# Altium schematic sheet Audio.SchDoc(Audio)
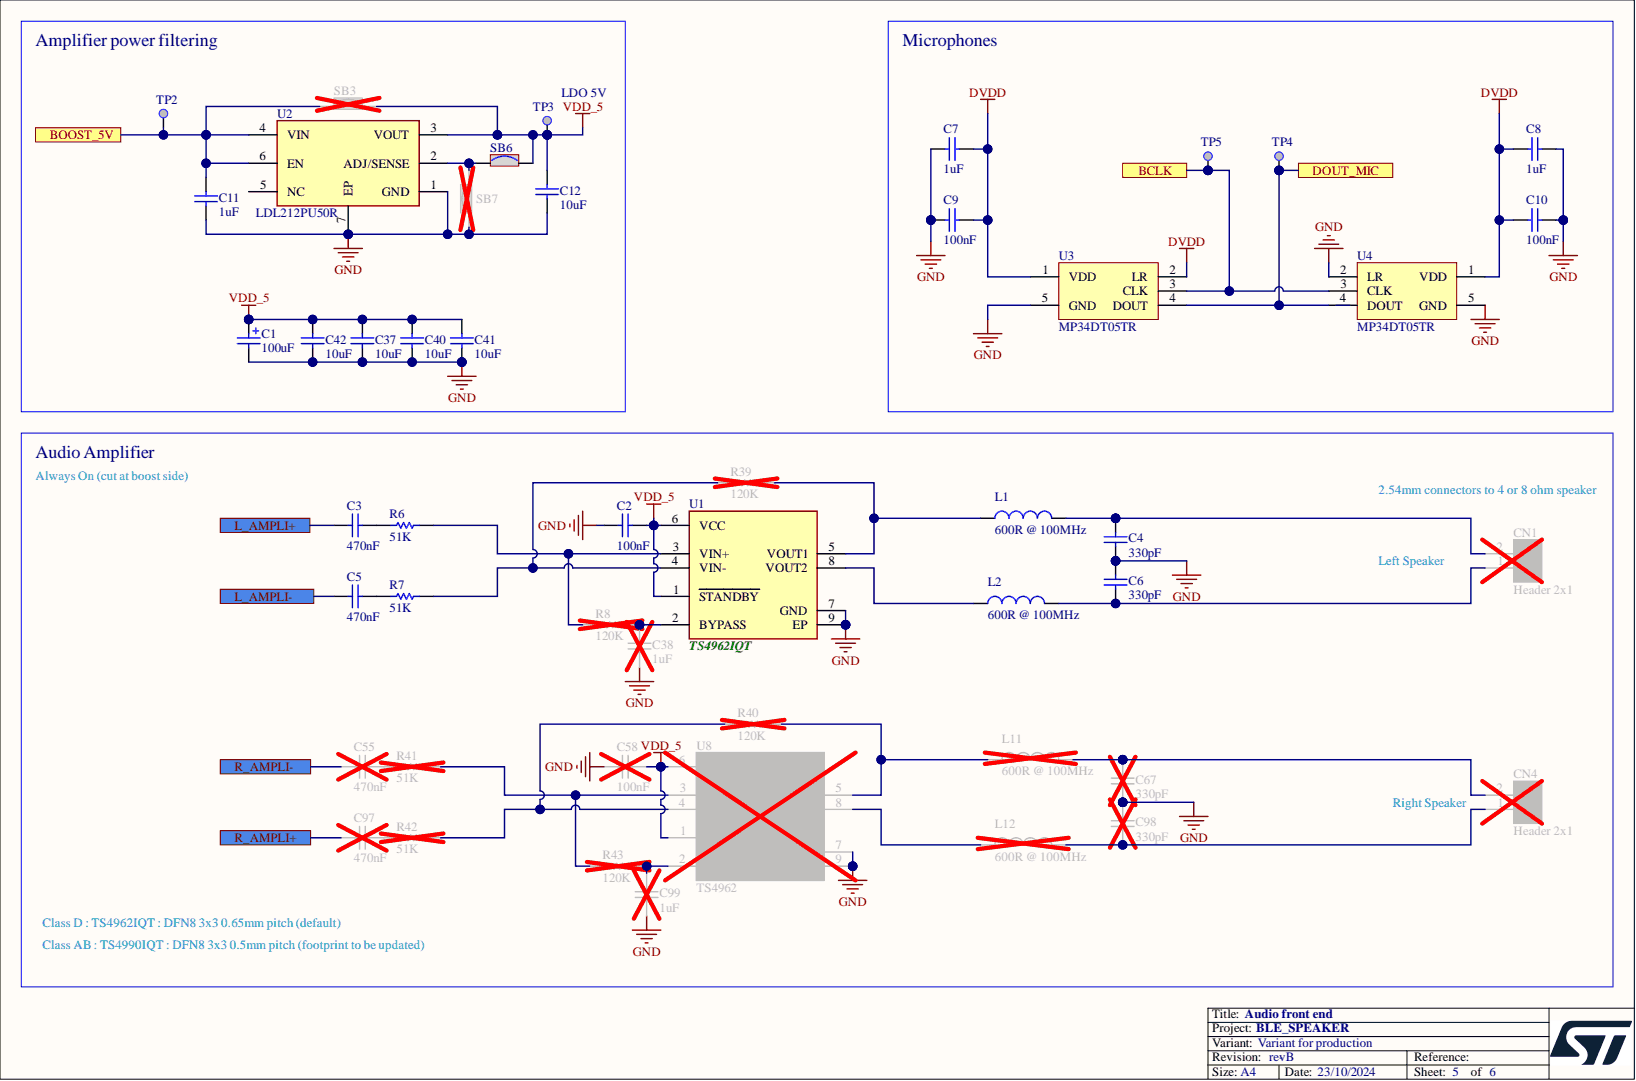
[diagram p0: Audio.SchDoc]

## Parts
C1  value 100uF  [CPOL_TCJ-B_1210_H210]  (hidden comment: CAP_POL)
C2  value 100nF  [C0201_H0P3]  (hidden comment: Capacitor)
C3  value 470nF  [C0402]  (hidden comment: Capacitor)
C4  value 330pF  [C0402]  (hidden comment: Capacitor)
C5  value 470nF  [C0402]  (hidden comment: Capacitor)
C6  value 330pF  [C0402]  (hidden comment: Capacitor)
C7  value 1uF  [C0402]  (hidden comment: Capacitor)
C8  value 1uF  [C0402]  (hidden comment: Capacitor)
C9  value 100nF  [C0201_H0P3]  (hidden comment: Capacitor)
C10  value 100nF  [C0201_H0P3]  (hidden comment: Capacitor)
C11  value 1uF  [C0402]  (hidden comment: Capacitor)
C12  value 10uF  [C0402]  (hidden comment: Capacitor)
C37  value 10uF  [C0402]  (hidden comment: Capacitor)
C38  value 1uF  [C0402]  (hidden comment: Capacitor)
C40  value 10uF  [C0402]  (hidden comment: Capacitor)
C41  value 10uF  [C0402]  (hidden comment: Capacitor)
C42  value 10uF  [C0402]  (hidden comment: Capacitor)
C55  value 470nF  [C0402]  (hidden comment: Capacitor)
C58  value 100nF  [C0201_H0P3]  (hidden comment: Capacitor)
C67  value 330pF  [C0402]  (hidden comment: Capacitor)
C97  value 470nF  [C0402]  (hidden comment: Capacitor)
C98  value 330pF  [C0402]  (hidden comment: Capacitor)
C99  value 1uF  [C0402]  (hidden comment: Capacitor)
CN1  Header 2x1  [CON_SAMTEC_TSW-102-07-G-S]
CN4  Header 2x1  [CON_SAMTEC_TSW-102-07-G-S]
L1  value 600R @ 100MHz  [IND_0603_H0P8]  (hidden comment: Inductor)
L2  value 600R @ 100MHz  [IND_0603_H0P8]  (hidden comment: Inductor)
L11  value 600R @ 100MHz  [IND_0603_H0P8]  (hidden comment: Inductor)
L12  value 600R @ 100MHz  [IND_0603_H0P8]  (hidden comment: Inductor)
R6  value 51K  [R0402]  (hidden comment: Resistor)
R7  value 51K  [R0402]  (hidden comment: Resistor)
R8  value 120K  [R0402]  (hidden comment: Resistor)
R39  value 120K  [R0402]  (hidden comment: Resistor)
R40  value 120K  [R0402]  (hidden comment: Resistor)
R41  value 51K  [R0402]  (hidden comment: Resistor)
R42  value 51K  [R0402]  (hidden comment: Resistor)
R43  value 120K  [R0402]  (hidden comment: Resistor)
SB3  SB_ON  [SOLDER-BRIDGE_R0201_0R]  (value not drawn)
SB6  SB_ON  [SOLDER-BRIDGE_R0201_0R]  (value not drawn)
SB7  SB_ON  [SOLDER-BRIDGE_R0201_0R]  (value not drawn)
TP2  Test Point  [TP_SMD_1MM]  (value not drawn)  (no BOM)
TP3  Test Point  [TP_SMD_1MM]  (value not drawn)  (no BOM)
TP4  Test Point  [TP_SMD_1MM]  (value not drawn)  (no BOM)
TP5  Test Point  [TP_SMD_1MM]  (value not drawn)  (no BOM)
U1  TS4962IQT  [SON50P300X300X100-9N-D]
U2  LDL212PU50R  [SON95P300X300X100-7N-D]
U3  MP34DT05TR  [MIC_HCLGA_3X4X1_4LD]
U4  MP34DT05TR  [MIC_HCLGA_3X4X1_4LD]
U8  TS4962  [SON50P300X300X100-9N-D]

## Ports
BCLK, BOOST_5V, DOUT_MIC, L_AMPLI+, L_AMPLI-, R_AMPLI+, R_AMPLI-

## Nets
DVDD: C7.1 C8.1 C9.2 C10.2 U3.1(VDD) U3.2(LR) U4.1(VDD)
GND: C1.2 C2.1 C4.2 C6.1 C7.2 C8.2 C9.1 C10.1 C11.2 C12.2 C37.2 C38.1 C40.2 C41.2 C42.2 C58.2 C67.2 C98.1 C99.1 SB7.2 U1.7(GND) U1.9(EP) U2.1(GND) U2.7(EP) U3.5(GND) U4.2(LR) U4.5(GND) U8.7(GND) U8.9(EP)
VDD_5: C1.1 C2.2 C12.1 C37.1 C40.1 C41.1 C42.1 C58.1 SB3.2 SB6.1 TP3.1 U1.1(STANDBY) U1.6(VCC) U2.3(VOUT) U8.1(STANDBY) U8.6(VCC)
BCLK: TP5.1 U3.3(CLK) U4.3(CLK) ; port BCLK
BOOST_5V: C11.1 SB3.1 TP2.1 U2.4(VIN) U2.6(EN) ; port BOOST_5V
DOUT_MIC: TP4.1 U3.4(DOUT) U4.4(DOUT) ; port DOUT_MIC
NetC3_1: C3.1 R6.2
NetC3_2: C3.2
NetC4_1: C4.1 CN1.2 L1.2
NetC5_1: C5.1 R7.2
NetC5_2: C5.2
NetC6_2: C6.2 CN1.1 L2.2
NetC38_2: C38.2 R8.1 U1.2(BYPASS)
NetC55_1: C55.1 R41.2
NetC55_2: C55.2
NetC67_1: C67.1 CN4.2 L11.2
NetC97_1: C97.1 R42.2
NetC97_2: C97.2
NetC98_2: C98.2 CN4.1 L12.2
NetC99_2: C99.2 R43.1 U8.2(BYPASS)
NetL1_1: L1.1 R39.1 U1.5(VOUT1)
NetL2_1: L2.1 U1.8(VOUT2)
NetL11_1: L11.1 R40.1 U8.5(VOUT1)
NetL12_1: L12.1 U8.8(VOUT2)
NetR6_1: R6.1 R8.2 U1.3(VIN+)
NetR7_1: R7.1 R39.2 U1.4(VIN-)
NetR40_2: R40.2 R42.1 U8.4(VIN-)
NetR41_1: R41.1 R43.2 U8.3(VIN+)
NetSB6_2: SB6.2 SB7.1 U2.2(ADJ/SENSE)

Not connected: U2 5(NC)

## Library data of the parts
C1 = MCD-01796: Capacitor, Tantalum, 100uF, 6V3, 40mR, +/-20%,  Type_B (3528 metric), T=1.9mm; Manufacturer: AVX; Manufacturer_Part_Number: TCJB107M006R0040; Part_Preference: Recommended; Component_Country_Of_Origin: Czech Republic; Database Table Name: MCD_PARTS$; ID: 3896; ShowFields: YES; Component Kind: Standard; ComponentLink1URL: http://tunmcdsvn.tun.st.com/Component_Documentation_Library/Trunk/Capacitor/AVX_TCJ_Series; Internal_Part_Number: MCD-01796; Library Name: MCD_PARTS_database.DbLib; Order_Code_Digikey: 478-9994-2-ND; Order_Code_Farnell: 2728622; Order_Code_RS: N/A; Package: 3528 (metric); Part_Type: Capacitor; Revision: July-2002: Re-released for DXP Platform.; Status: Released
C2 C9 C10 C58 = MCD-00697: Capacitor, MLCC, 100nF,10V, X5R, +/-10%, 0201, T=0.3mm; Manufacturer: TDK; Manufacturer_Part_Number: C0603X5R1A104K030BC; Part_Preference: Recommended; Component_Country_Of_Origin: Unknown; Database Table Name: MCD_PARTS$; ID: 3046; ShowFields: YES; Component Kind: Standard; ComponentLink1URL: http://tunmcdsvn.tun.st.com/Component_Documentation_Library/Trunk/Capacitor/TDK_MCC_Series; Internal_Part_Number: MCD-00697; Library Name: MCD_PARTS_database.DbLib; Order_Code_Digikey: 445-7318-1-ND; Order_Code_Farnell: 2524957; Order_Code_RS: N/A; Package: 0201; Part_Type: Capacitor; Status: Released
C3 C5 C55 C97 = MCD-03075: Capacitor, MLCC, 470nF, 25V, X5R, +/-10%, 0402, T=0.5mm; Manufacturer: YAGEO; Manufacturer_Part_Number: CC0402KRX5R8BB474; Part_Preference: Recommended; Component_Country_Of_Origin: China, Taiwan; Database Table Name: MCD_PARTS$; ID: 5191; ShowFields: YES; Component Kind: Standard; ComponentLink1URL: http://tunmcdsvn.tun.st.com/Component_Documentation_Library/Trunk/Capacitor/YAGEO_X5R_Series/; Internal_Part_Number: MCD-03075; Library Name: MCD_PARTS_database.DbLib; Order_Code_Digikey: 13-CC0402KRX5R8BB474TR-ND; Order_Code_Farnell: 3871700; Order_Code_RS: N/A; Package: 0402; Part_Type: Capacitor; Status: Checked
C4 C6 C67 C98 = MCD-01579: Capacitor, MLCC, 330pF, 50V, NPO, +/-5%, 0402, T=0.5mm; Manufacturer: YAGEO; Manufacturer_Part_Number: CC0402JRNPO9BN331; Part_Preference: Recommended; Component_Country_Of_Origin: China; Database Table Name: MCD_PARTS$; ID: 3679; ShowFields: YES; Component Kind: Standard; ComponentLink1URL: http://tunmcdsvn.tun.st.com/Component_Documentation_Library/Trunk/Capacitor/YAGEO_NP0_Series; Internal_Part_Number: MCD-01579; Library Name: MCD_PARTS_database.DbLib; Order_Code_Digikey: 311-1667-1-ND; Order_Code_Farnell: N/A; Order_Code_RS: N/A; Package: 0402; Part_Type: Capacitor; Status: Released
C7 C8 C11 C38 C99 = MCD-01722: Capacitor, MLCC, 1uF, 10V, X5R, +/-10%, 0402, T=0.5mm; Manufacturer: YAGEO; Manufacturer_Part_Number: CC0402KRX5R6BB105; Part_Preference: Recommended; Component_Country_Of_Origin: China; Database Table Name: MCD_PARTS$; ID: 3822; ShowFields: YES; Component Kind: Standard; ComponentLink1URL: http://tunmcdsvn.tun.st.com/Component_Documentation_Library/Trunk/Capacitor/YAGEO_X5R_Series; Internal_Part_Number: MCD-01722; Library Name: MCD_PARTS_database.DbLib; Order_Code_Digikey: 311-1439-1-ND; Order_Code_Farnell: 1458896; Order_Code_RS: N/A; Package: 0402; Part_Type: Capacitor; Status: Released
C12 C37 C40-C42 = MCD-03140: Capacitor, MLCC, 10uF, 6.3V, X5R, +/-20%, 0402, T=0.5mm; Manufacturer: MURATA; Manufacturer_Part_Number: GRM155R60J106ME05D; Part_Preference: Recommended; Component_Country_Of_Origin: China, Japan; Database Table Name: MCD_PARTS$; ID: 5256; ShowFields: YES; Component Kind: Standard; ComponentLink1URL: http://tunmcdsvn.tun.st.com/Component_Documentation_Library/Trunk/Capacitor/MURATA_GRM_Series; Internal_Part_Number: MCD-03140; Library Name: MCD_PARTS_database.DbLib; Order_Code_Digikey: 490-GRM155R60J106ME05DTR-ND; Order_Code_Farnell: 3784428; Order_Code_RS: N/A; Package: 0402; Part_Type: Capacitor; Status: Released
CN1 CN4 = MCD-00032: Header, 2x1, 2.54mm, VR, TH, T=5.84mm/2.54mm; Manufacturer: SAMTEC; Manufacturer_Part_Number: TSW-102-07-G-S; Part_Preference: Recommended; Part_Type: Connector; Component_Country_Of_Origin: China, Costa Rica, Malaysia, USA, Vietnam; Database Table Name: MCD_PARTS$; ID: 2484; Order_Code_RS: 2505044; ShowFields: YES; Component Kind: Standard; ComponentLink1URL: http://tunmcdsvn.tun.st.com/Component_Documentation_Library/Trunk/Connector/SAMTEC_TSW_Series/; Internal_Part_Number: MCD-00032; Library Name: MCD_PARTS_database.DbLib; Order_Code_Digikey: SAM1029-02-ND; Order_Code_Farnell: 2505044; Status: Released; Value: Header 2x1
L1 L2 L11 L12 = MCD-00593: Ferrite bead, 600R @ 100MHz, +/-25%, 1300mA, 150mR, 0603, T=0.95mm; Manufacturer: MURATA; Manufacturer_Part_Number: BLM18KG601SN1D; Part_Preference: Recommended; Component_Country_Of_Origin: Japan°°; Database Table Name: MCD_PARTS$; ID: 3152; Order_Code_RS: 167-4203; ShowFields: YES; Component Kind: Standard; ComponentLink1URL: http://tunmcdsvn.tun.st.com/Component_Documentation_Library/Trunk/Inductor/MURATA_BLM_Series; Internal_Part_Number: MCD-00593; Library Name: MCD_PARTS_database.DbLib; Order_Code_Digikey: 490-5258-2-ND; Order_Code_Farnell: 1781094; Package: 0603; Part_Type: Inductor; Status: Released
R6 R7 R41 R42 = MCD-00294: Resistor, 51K, 0402, +/-1%, 1/16W; Manufacturer: YAGEO; Manufacturer_Part_Number: RC0402FR-0751KL; Part_Preference: Recommended; Component_Country_Of_Origin: China; Database Table Name: MCD_PARTS$; ID: 2575; ShowFields: YES; Component Kind: Standard; ComponentLink1URL: http://tunmcdsvn.tun.st.com/Component_Documentation_Library/Trunk/Resistor/YAGEO_RC_L_Series; Internal_Part_Number: MCD-00294; Library Name: MCD_PARTS_database.DbLib; Order_Code_Digikey: 311-51.0KLRCT-ND; Order_Code_Farnell: 1458806; Order_Code_RS: N/A; Package: 0402; Part_Type: Resistor; Status: Released
R8 R39 R40 R43 = MCD-02614: Resistor, 120K, 0402, +/-0.1%, 1/16W, +/-25ppm/°C; Manufacturer: YAGEO; Manufacturer_Part_Number: RT0402BRD07120KL; Part_Preference: Recommended; Component_Country_Of_Origin: Taiwan; Database Table Name: MCD_PARTS$; ID: 4720; ShowFields: YES; Component Kind: Standard; ComponentLink1URL: http://tunmcdsvn.tun.st.com/Component_Documentation_Library/Trunk/Resistor/YAGEO_RT_Series; Internal_Part_Number: MCD-02614; Library Name: MCD_PARTS_database.DbLib; Order_Code_Digikey: YAG4168TR-ND; Order_Code_Farnell: 3496456RL; Order_Code_RS: N/A; Package: 0402; Part_Type: Resistor; Status: Released
SB3 SB6 SB7 = MCD-03219: Solder Bridge ON, 0R, 0201, 1/20W; Manufacturer: YAGEO; Manufacturer_Part_Number: RC0201JR-070RL; Part_Preference: Recommended; Part_Type: Misc; Component_Country_Of_Origin: China; Database Table Name: MCD_PARTS$; ID: 5335; Order_Code_RS: 198-7623; ShowFields: YES; Component Kind: Standard; ComponentLink1URL: http://tunmcdsvn.tun.st.com/Component_Documentation_Library/Trunk/Resistor/YAGEO_RC_L_Series; Internal_Part_Number: MCD-03219; Library Name: MCD_PARTS_database.DbLib; Order_Code_Digikey: 311-0.0NTR-ND; Order_Code_Farnell: 1458895; Status: Checked; Value: 0R
  SB3: Package: SB0201
  SB6 SB7: Package: 0201
TP2-TP5 = MCD-00074: Test Point 1mm; Part_Preference: Recommended; Part_Type: Misc; Component_Country_Of_Origin: Unknown; Database Table Name: MCD_PARTS$; ID: 2518; ShowFields: YES; ComponentLink1URL: http://tunmcdsvn.tun.st.com/Component_Documentation_Library/Trunk/Misc/; Internal_Part_Number: MCD-00074; Library Name: MCD_PARTS_database.DbLib; Manufacturer: N/A; Manufacturer_HQ_COO: Unknown; Manufacturer_Part_Number: N/A; Order_Code_Digikey: N/A; Order_Code_Farnell: N/A; Order_Code_RS: N/A; Status: Released; Value: Test Point 1mm
U1 U8 = TS4990IQT: Audio Amplifiers 1.2W audio pow. amp.; Manufacturer_Name: STMicroelectronics; Geometry.Height: 1mm; Arrow Price/Stock: https://www.arrow.com/en/products/ts4990iqt/stmicroelectronics?region=nac; Component Kind: Standard; Datasheet Link: http://www.st.com/content/ccc/resource/technical/document/datasheet/e6/5f/23/8e/d0/ac/43/e8/CD00003243.pdf/files/CD00003243.pdf/jcr:content/translations/en.CD00003243.pdf; Library Name: TS4990IQT.SchLib; Mouser Part Number: 511-TS4990IQT; Mouser Price/Stock: https://www.mouser.co.uk/ProductDetail/STMicroelectronics/TS4990IQT?qs=G1TAZZK1RgLQwbSE6RNG8A%3D%3D
  U1: Manufacturer_Part_Number: TS4962IQT
  U8: Arrow Part Number: TS4990IQT; Manufacturer_Part_Number: TS4990IQT
U2 = LDL212PU50R: LDO Voltage Regulators Linear Voltage Regulators & Vref; Manufacturer_Name: STMicroelectronics; Geometry.Height: 1mm; Arrow Part Number: LDL212PU50R; Arrow Price/Stock: https://www.arrow.com/en/products/ldl212pu50r/stmicroelectronics?utm_currency=USD&region=nac; Component Kind: Standard; Datasheet Link: https://componentsearchengine.com/Datasheets/1/LDL212PU50R.pdf; Library Name: LDL212PU50R.SchLib; Manufacturer_Part_Number: LDL212PU50R; Mouser Part Number: 511-LDL212PU50R; Mouser Price/Stock: https://www.mouser.co.uk/ProductDetail/STMicroelectronics/LDL212PU50R?qs=WHlX%252B%252B9%2FRwDERLqLAAdTnw%3D%3D
U3 U4 = MCD-00617: MEMS, audio sensor, omnidirectional digital microphone; BMS_Part_Number: 9188013; Manufacturer: STMICROELECTRONICS; Manufacturer_HQ_COO: EU; Part_Preference: Forbidden; Part_Type: IC; Database Table Name: MCD_PARTS$; ID: 3129; ShowFields: YES; Component Kind: Standard; ComponentLink1URL: http://tunmcdsvn.tun.st.com/Component_Documentation_Library/Trunk/IC/STMICROELECTRONICS_MP34DT05TR; Internal_Part_Number: MCD-00617; Library Name: MCD_PARTS_database.DbLib; Manufacturer_Part_Number: MP34DT05TR; Order_Code_Digikey: N/A; Order_Code_Farnell: N/A; Order_Code_RS: N/A; Package: HCLGA-4; Status: Obsolete; Value: MP34DT05TR
